# Altium schematic sheet gff.SchDoc(gff)
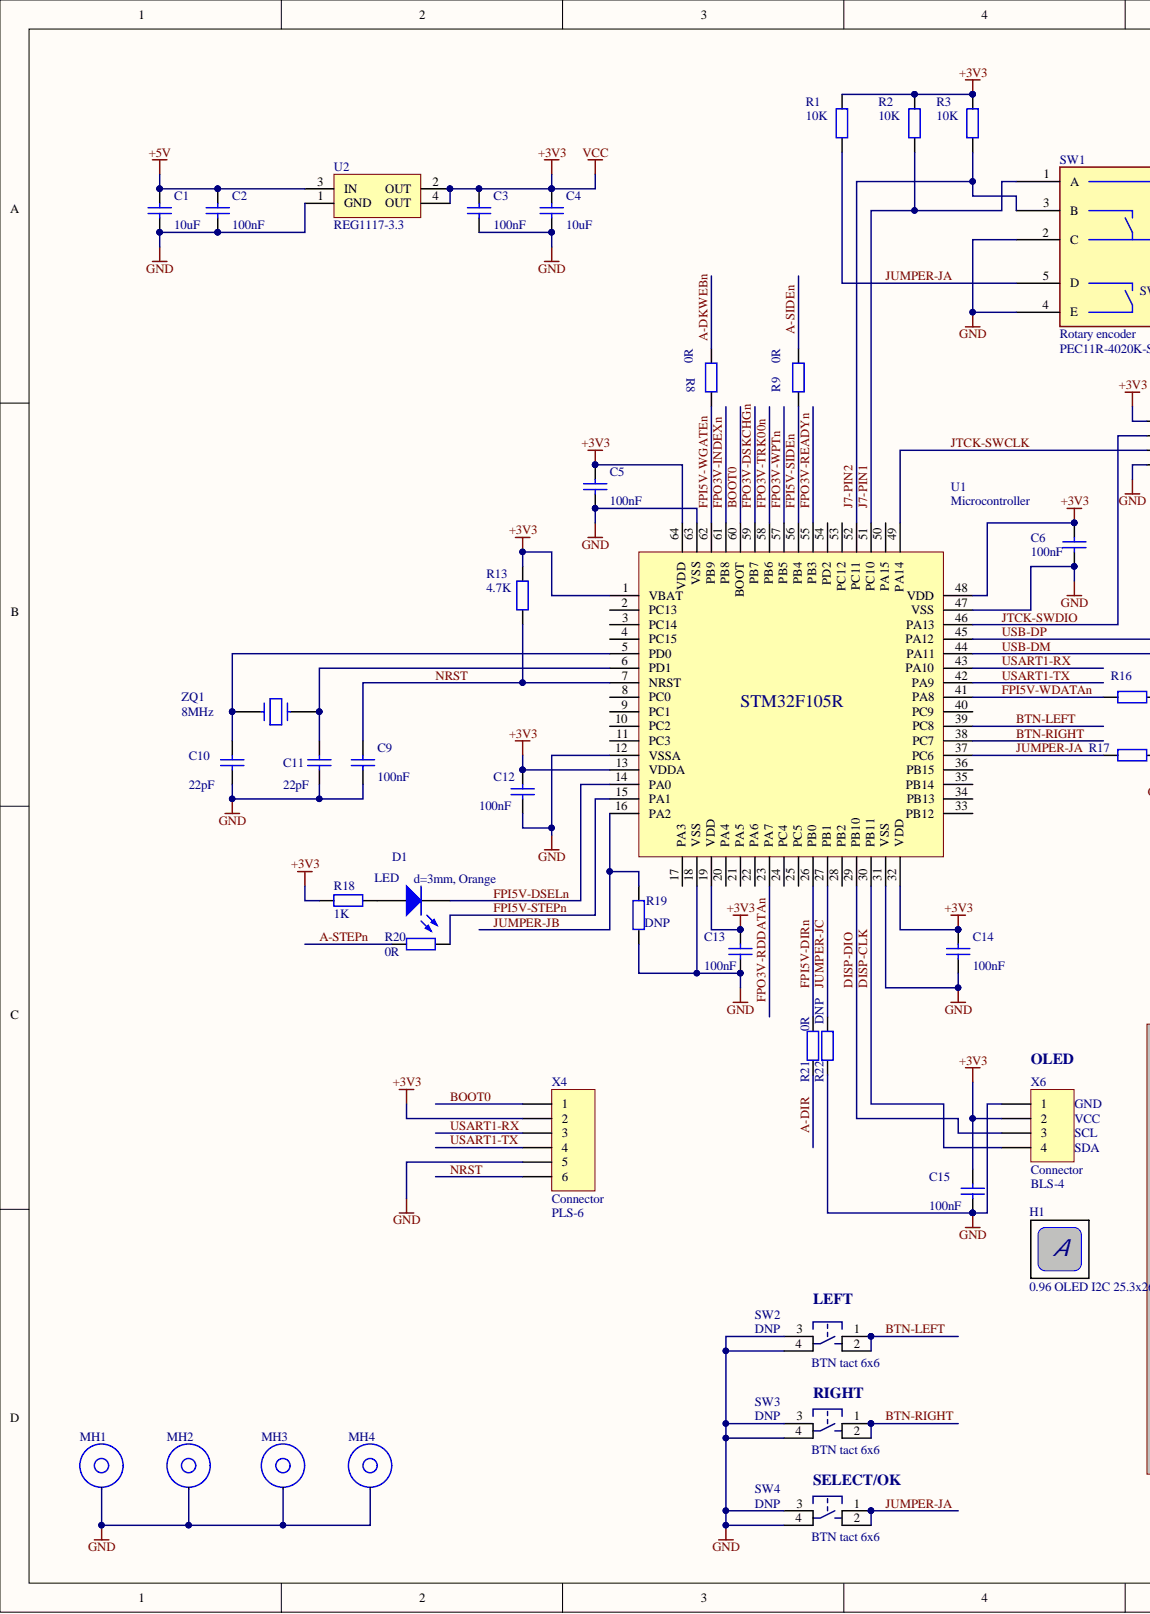
[diagram p0: gff.SchDoc, left]
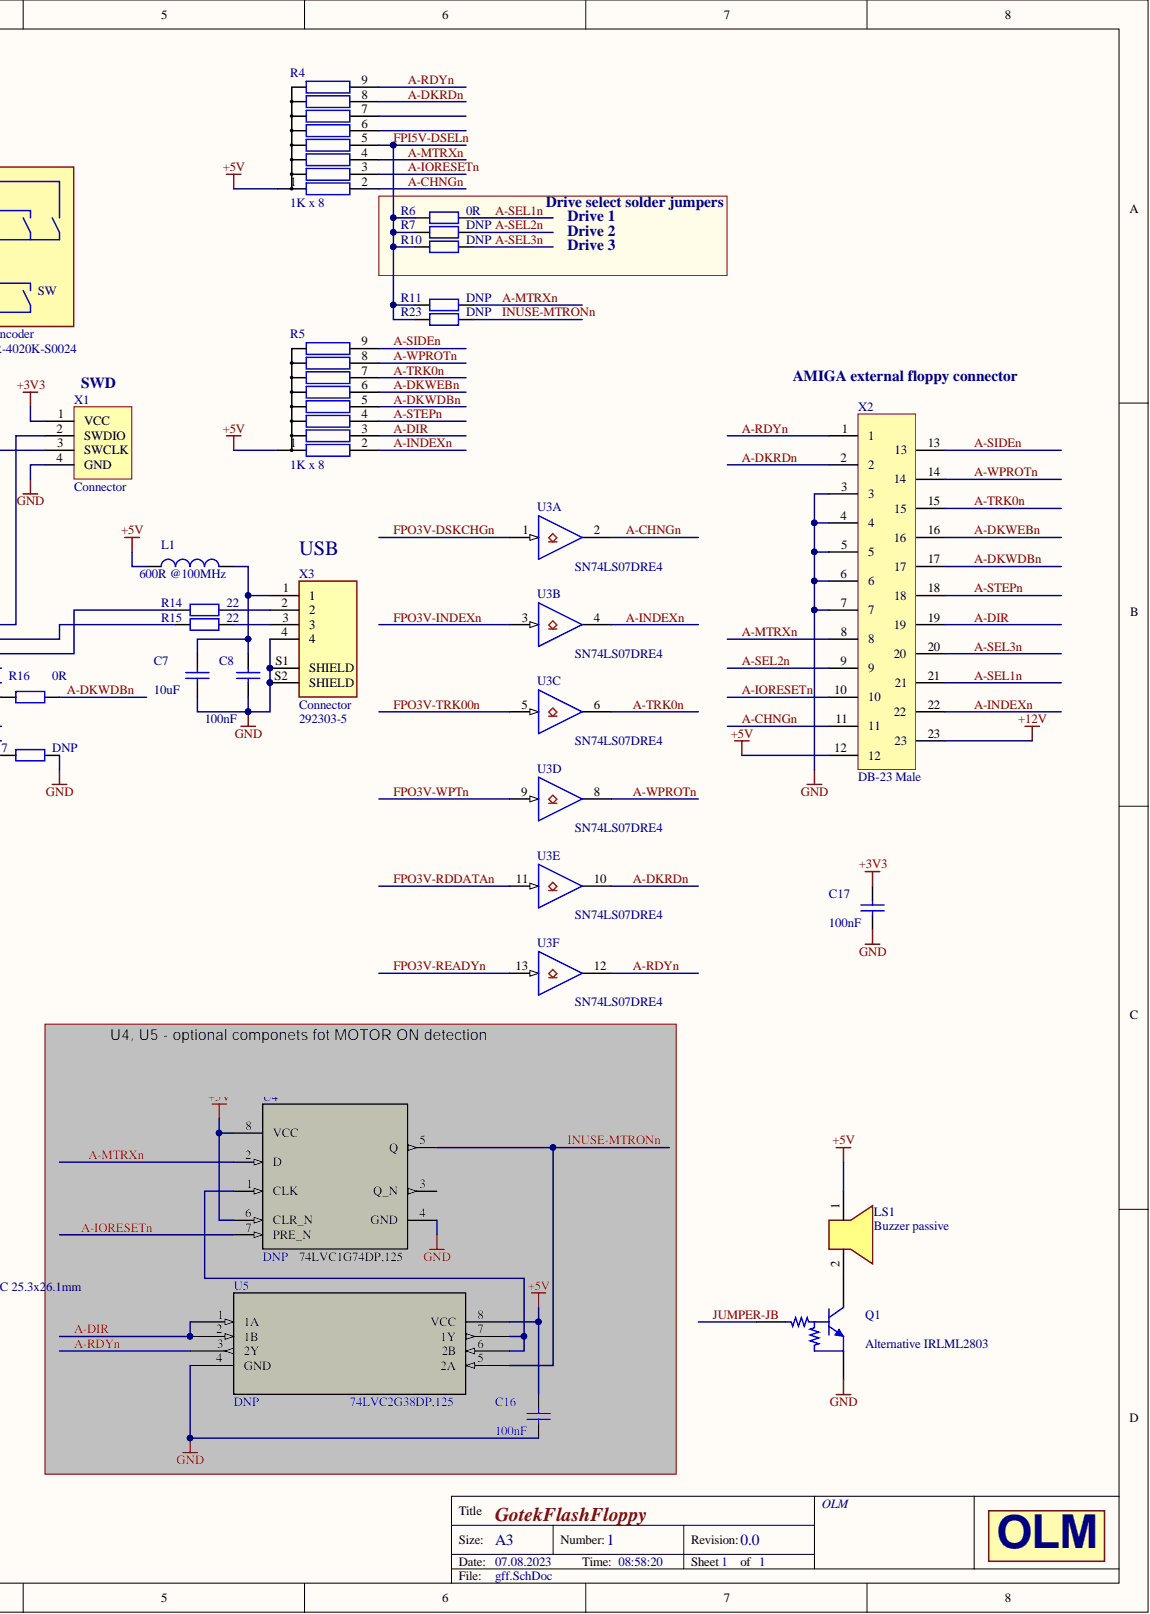
[diagram p1: gff.SchDoc, right]

## Parts
C1  value 10uF  [SM/C_1206]  (hidden comment: Capacitor)
C2  value 100nF  [SM/C_0603]  (hidden comment: Capacitor)
C3  value 100nF  [SM/C_0603]  (hidden comment: Capacitor)
C4  value 10uF  [SM/C_1206]  (hidden comment: Capacitor)
C5  value 100nF  [SM/C_0603]  (hidden comment: Capacitor)
C6  value 100nF  [SM/C_0603]  (hidden comment: Capacitor)
C7  value 10uF  [SM/C_1206]  (hidden comment: Capacitor)
C8  value 100nF  [SM/C_0603]  (hidden comment: Capacitor)
C9  value 100nF  [SM/C_0603]  (hidden comment: Capacitor)
C10  value 22pF  [SM/C_0603]  (hidden comment: Capacitor)
C11  value 22pF  [SM/C_0603]  (hidden comment: Capacitor)
C12  value 100nF  [SM/C_0603]  (hidden comment: Capacitor)
C13  value 100nF  [SM/C_0603]  (hidden comment: Capacitor)
C14  value 100nF  [SM/C_0603]  (hidden comment: Capacitor)
C15  value 100nF  [SM/C_0603]  (hidden comment: Capacitor)
C16  value 100nF  [SM/C_0603]  (hidden comment: Capacitor)
C17  value 100nF  [SM/C_0603]  (hidden comment: Capacitor)
D1  LED  value d=3mm, Orange  [LED_d3_green_sp8.64]
H1  value 0.96 OLED I2C 25.3x26.1mm  PArt: 0.96 OLED I2C 25.3x26.1mm  (hidden comment: OLED display)  (mechanical)
L1  value 600R @100MHz  [SM/L_1210]  (hidden comment: Ferrite bead)
LS1  Buzzer passive  [BUZZER_9mm]
MH1  MTHOLE_3  [MTHOLE_3.5MM/5MM]  (value not drawn)  (no BOM)
MH2  MTHOLE_3  [MTHOLE_3.5MM/5MM]  (value not drawn)  (no BOM)
MH3  MTHOLE_3  [MTHOLE_3.5MM/5MM]  (value not drawn)  (no BOM)
MH4  MTHOLE_3  [MTHOLE_3.5MM/5MM]  (value not drawn)  (no BOM)
Q1  Transistor  [SM/SOT23_123]  (value not drawn)
R1  value 10K  [SM/R_0603]  (hidden comment: Resistor)
R2  value 10K  [SM/R_0603]  (hidden comment: Resistor)
R3  value 10K  [SM/R_0603]  (hidden comment: Resistor)
R4  value 1K x 8  [RES_ARRAY_SIP9]  (hidden comment: Resistor network)
R5  value 1K x 8  [RES_ARRAY_SIP9]  (hidden comment: Resistor network)
R6  value 0R  [SM/R_0603]  (hidden comment: Resistor)
R7  value DNP  [SM/R_0603]  (hidden comment: Resistor)  (no BOM)
R8  value 0R  [SM/R_0603]  (hidden comment: Resistor)
R9  value 0R  [SM/R_0603]  (hidden comment: Resistor)
R10  value DNP  [SM/R_0603]  (hidden comment: Resistor)  (no BOM)
R11  value DNP  [SM/R_0603]  (hidden comment: Resistor)  (no BOM)
R13  value 4.7K  [SM/R_0603]  (hidden comment: Resistor)
R14  value 22  [SM/R_0603]  (hidden comment: Resistor)
R15  value 22  [SM/R_0603]  (hidden comment: Resistor)
R16  value 0R  [SM/R_0603]  (hidden comment: Resistor)
R17  value DNP  [SM/R_0603]  (hidden comment: Resistor)  (no BOM)
R18  value 1K  [SM/R_0603]  (hidden comment: Resistor)
R19  value DNP  [SM/R_0603]  (hidden comment: Resistor)  (no BOM)
R20  value 0R  [SM/R_0603]  (hidden comment: Resistor)
R21  value 0R  [SM/R_0603]  (hidden comment: Resistor)
R22  value DNP  [SM/R_0603]  (hidden comment: Resistor)  (no BOM)
R23  value DNP  [SM/R_0603]  (hidden comment: Resistor)  (no BOM)
SW1  Rotary encoder  value PEC11R-4020K-S0024  Part: PEC11R-4020K-S0024  [Encoder Bourns PEC11R-xx20F-S]
SW2  value BTN tact 6x6  Part: DNP  [BTN_6x6]  (hidden comment: Кнопка)  (no BOM)
SW3  value BTN tact 6x6  PArt: DNP  [BTN_6x6]  (hidden comment: Кнопка)  (no BOM)
SW4  value BTN tact 6x6  PArt: DNP  [BTN_6x6]  (hidden comment: Кнопка)  (no BOM)
U1  Microcontroller  [LQFP-64]
U2  value REG1117-3.3  [SM/SOT223_1234]  (hidden comment: LDO)
U3  value SN74LS07DRE4  Part: SN74LS07DRE4  [SOG.050/14/WG.244/L.325]  (hidden comment: IC)  (parts A,B,C,D,E,F)
U4  74LVC1G74DP,125  PArt: DNP  [TSSOP-8-1]  (no BOM)
U5  74LVC2G38DP,125  Part: DNP  [TSSOP-8-1]  (no BOM)
X1  Connector  [PLS-4]
X2  Connector  value DB-23 Male  Part: DB-23 Male  [SM/DB-23_EDGE]
X3  Connector  value 292303-5  MP: 292303-5  [TE_ 292303-5]
X4  Connector  value PLS-6  [HDR1X6]
X6  Connector  value BLS-4  [OLED-128x64-0.96_SMALL]
ZQ1  value 8MHz  [CRYSTAL_HC-49S]  (hidden comment: CRYSTAL)

## Nets
+3V3: C3.2 C4.2 C5.2 C6.2 C12.2 C13.2 C14.2 C15.2 C17.2 R1.1 R2.1 R3.1 R13.1 R18.1 U1.1(VBAT) U1.13(VDDA) U1.19(VDD) U1.32(VDD) U1.48(VDD) U1.64(VDD) U2.2(OUT) U2.4(OUT) U3.14 X1.1(VCC) X4.2 X6.2
+5V: C1.2 C2.2 C16.2 L1.1 LS1.1 R4.1 R5.1 U2.3(IN) U4.6(CLR_N) U4.8(VCC) U5.8(VCC) X2.12
+12V: X2.23
GND: C1.1 C2.1 C3.1 C4.1 C5.1 C6.1 C7.1 C8.1 C9.1 C10.2 C11.2 C12.1 C13.1 C14.1 C15.1 C16.1 C17.1 MH1.1 MH2.1 MH3.1 MH4.1 Q1.2 R17.2 R19.1 R22.1 SW1.2(C) SW1.4(E) SW2.3 SW2.4 SW3.3 SW3.4 SW4.3 SW4.4 U1.12(VSSA) U1.18(VSS) U1.31(VSS) U1.47(VSS) U1.63(VSS) U2.1(GND) U3.7 U4.4(GND) U5.4(GND) X1.4(GND) X2.3 X2.4 X2.5 X2.6 X2.7 X3.4 X3.S1(SHIELD) X3.S2(SHIELD) X4.5 X6.1
A-CHNGN: R4.2 U3.2 X2.11
A-DIR: R5.3 R21.1 U5.1(1A) U5.2(1B) X2.19
A-DKRDN: R4.8 U3.10 X2.2
A-DKWDBN: R5.5 R16.2 X2.17
A-DKWEBN: R5.6 R8.2 X2.16
A-INDEXN: R5.2 U3.4 X2.22
A-IORESETN: R4.3 U4.7(PRE_N) X2.10
A-MTRXN: R4.4 R11.2 U4.2(D) X2.8
A-RDYN: R4.9 U3.12 U5.3(2Y) X2.1
A-SEL1N: R6.2 X2.21
A-SEL2N: R7.2 X2.9
A-SEL3N: R10.2 X2.20
A-SIDEN: R5.9 R9.2 X2.13
A-STEPN: R5.4 R20.1 X2.18
A-TRK0N: R5.7 U3.6 X2.15
A-WPROTN: R5.8 U3.8 X2.14
BOOT0: U1.60(BOOT) X4.1
BTN-LEFT: SW2.1 SW2.2 U1.39(PC8)
BTN-RIGHT: SW3.1 SW3.2 U1.38(PC7)
DISP-CLK: U1.30(PB11) X6.4
DISP-DIO: U1.29(PB10) X6.3
FPI5V-DIRN: R21.2 U1.26(PB0)
FPI5V-DSELN: D1.2 R4.5 R6.1 R7.1 R10.1 R11.1 R23.1 U1.14(PA0)
FPI5V-SIDEN: R9.1 U1.56(PB4)
FPI5V-STEPN: R20.2 U1.15(PA1)
FPI5V-WDATAN: R16.1 U1.41(PA8)
FPI5V-WGATEN: R8.1 U1.62(PB9)
FPO3V-DSKCHGN: U1.59(PB7) U3.1
FPO3V-INDEXN: U1.61(PB8) U3.3
FPO3V-RDDATAN: U1.23(PA7) U3.11
FPO3V-READYN: U1.55(PB3) U3.13
FPO3V-TRK00N: U1.58(PB6) U3.5
FPO3V-WPTN: U1.57(PB5) U3.9
INUSE-MTRONN: R23.2 U4.5(Q) U5.5(2A)
J7-PIN1: R2.2 SW1.1(A) U1.51(PC10)
J7-PIN2: R3.2 SW1.3(B) U1.52(PC11)
JTCK-SWCLK: U1.49(PA14) X1.3(SWCLK)
JTCK-SWDIO: U1.46(PA13) X1.2(SWDIO)
JUMPER-JA: R1.2 R17.1 SW1.5(D) SW4.1 SW4.2 U1.37(PC6)
JUMPER-JB: Q1.1 R19.2 U1.16(PA2)
JUMPER-JC: R22.2 U1.27(PB1)
NRST: C9.2 R13.2 U1.7(NRST) X4.6
USART1-RX: U1.43(PA10) X4.3
USART1-TX: U1.42(PA9) X4.4
USB-DM: R14.1 U1.44(PA11)
USB-DP: R15.1 U1.45(PA12)
NetC7_2: C7.2 C8.2 L1.2 X3.1
NetC10_1: C10.1 U1.5(PD0) ZQ1.2
NetC11_1: C11.1 U1.6(PD1) ZQ1.1
NetD1_1: D1.1 R18.2
NetLS1_2: LS1.2 Q1.3
NetR14_2: R14.2 X3.2
NetR15_2: R15.2 X3.3
NetU4_1: U4.1(CLK) U5.6(2B) U5.7(1Y)

Not connected: R4 6 7; U1 2(PC13) 3(PC14) 4(PC15) 8(PC0) 9(PC1) 10(PC2) 11(PC3) 17(PA3) 20(PA4) 21(PA5) 22(PA6) 24(PC4) 25(PC5) 28(PB2) 33(PB12) 34(PB13) 35(PB14) 36(PB15) 40(PC9) 50(PA15) 53(PC12) 54(PD2); U4 3(Q_N)

## Library data of the parts
C1 C4 C7 = Cap ceramic: Ceramic capacitor SMD; Manufacturer: Kemet; Part: C1206C106K4RACTU; Component Kind: Standard; Library Name: New.SCHLIB
C2 C3 C5 C6 C8 C9 C12-C17 = Cap ceramic: Ceramic capacitor SMD; Manufacturer: Samsung; Part: CL10B104KB8NNNL; Component Kind: Standard; Library Name: New.SCHLIB
C10 C11 = Cap: Ceramic capacitor SMD; Manufacturer: Yageo; Part: CC0603JPNPO9BN220; Component Kind: Standard; LatestRevisionDate: 17-Jul-2002; LatestRevisionNote: Re-released for DXP Platform.; Library Name: Miscellaneous Devices.IntLib; PackageReference: RAD-0.3; Published: 8-Jun-2000; Signal Integrity: Cap; Simulation: CAP; Publisher: Altium Limited
D1 = LED0: LED d3mm; Part: LED 3mm Orange; Примечание: Kingbright; Component Kind: Standard; LatestRevisionDate: 08-Jul-2005; LatestRevisionNote: Mech Layer 1 removed.; Library Name: Miscellaneous Devices.IntLib; PackageDescription: LED; 2 Leads; PackageReference: LED-0; Published: 8-Jun-2000; Simulation: LED0; Publisher: Altium Limited
H1 = Display: 0.96 OLED display; Library Name: MECH_Library_home.SchLib
L1 = Inductor: Manufacturer: Bourns; Part: BLM31PG601SN1L; Code_IEC: 1005; Code_JEITA: 0402; Component Kind: Standard; Description: Ferrite bead; Library Name: Miscellaneous Devices.IntLib; PackageDescription: Chip Inductor; PackageReference: 1206; Signal Integrity: Inductor; Simulation: INDUCTOR
LS1 = Buzzer_1: 4-6V small buzzer (9mm); Manufacturer: Soberton; Part: GT-0905A; Value: GT-0905A; Component Kind: Standard; Library Name: New.SCHLIB
Q1 = PDTC114ET: NPN Resistor-equipped Transistor; Part: Alternative IRLML2803; Component Kind: Standard; ComponentLink1Description: Manufacturer Link; ComponentLink1URL: http://www.semiconductors.philips.com/; ComponentLink2Description: Datasheet; ComponentLink2URL: http://www.semiconductors.philips.com/acrobat/datasheets/PDTC114E_SERIES_10.pdf; DatasheetVersion: 15-Apr-1999; Library Name: Philips Discrete BJT - Low Power.IntLib; PackageDescription: SOT-23; 3 Leads; Body 3.0 x 2.5 mm, inc. leads (LxW max); PackageReference: SOT23; PackageVersion: May-1999; Published: 17-Sep-2003; Signal Integrity: PDTC114ET; Simulation: PDTC114ET; Value: PDTC114ET; Publisher: Altium Limited
R1-R3 = Res2: Resistor SMD; PArt: 10k 5% 0603; Component Kind: Standard; LatestRevisionDate: 17-Jul-2002; LatestRevisionNote: Re-released for DXP Platform.; Library Name: Miscellaneous Devices.IntLib; PackageReference: AXIAL-0.4; Published: 8-Jun-2000; Signal Integrity: Res; Simulation: RESISTOR; Publisher: Altium Limited
R4 R5 = RES_PACK_8: SIP bussed resistor network. 8 resistors; Manufacturer: Bourns; Part: 4609X-101-102LF; Component Kind: Standard; Library Name: New.SCHLIB
R6 R8 R9 R16 R20 R21 = Res2: Resistor SMD; Part: 0R 5% 0603; Component Kind: Standard; LatestRevisionDate: 17-Jul-2002; LatestRevisionNote: Re-released for DXP Platform.; Library Name: Miscellaneous Devices.IntLib; PackageReference: AXIAL-0.4; Published: 8-Jun-2000; Signal Integrity: Res; Simulation: RESISTOR; Publisher: Altium Limited
R7 R10 R11 R17 R19 R22 R23 = Res2: Resistor SMD; LatestRevisionDate: 17-Jul-2002; LatestRevisionNote: Re-released for DXP Platform.; Library Name: Miscellaneous Devices.IntLib; PackageReference: AXIAL-0.4; Part: DNP 0603; Published: 8-Jun-2000; Signal Integrity: Res; Simulation: RESISTOR; Publisher: Altium Limited
R13 = Res2: Resistor SMD; Part: 4.7k 5% 0603; Component Kind: Standard; LatestRevisionDate: 17-Jul-2002; LatestRevisionNote: Re-released for DXP Platform.; Library Name: Miscellaneous Devices.IntLib; PackageReference: AXIAL-0.4; Published: 8-Jun-2000; Signal Integrity: Res; Simulation: RESISTOR; Publisher: Altium Limited
R14 R15 = Res2: Resistor SMD; Part: 22R 5% 0603; Component Kind: Standard; LatestRevisionDate: 17-Jul-2002; LatestRevisionNote: Re-released for DXP Platform.; Library Name: Miscellaneous Devices.IntLib; PackageReference: AXIAL-0.4; Published: 8-Jun-2000; Signal Integrity: Res; Simulation: RESISTOR; Publisher: Altium Limited
R18 = Res2: Resistor SMD; Part: 1k 5% 0603; Component Kind: Standard; LatestRevisionDate: 17-Jul-2002; LatestRevisionNote: Re-released for DXP Platform.; Library Name: Miscellaneous Devices.IntLib; PackageReference: AXIAL-0.4; Published: 8-Jun-2000; Signal Integrity: Res; Simulation: RESISTOR; Publisher: Altium Limited
SW1 = ENCODER_SW: Rotary encoder with a switch; Manufacturer: Bourns; Component Kind: Standard; Library Name: New_home.SCHLIB
SW2-SW4 = Button 4 pin: small tac switch 4pin; Library Name: New_home.SCHLIB
U1 = STM32F105RxT: ST MCU with CAN and USB; Manufacturer: ST Micro; Part: STM32F105RBT6; Value: STM32F105RBT6; Component Kind: Standard; Library Name: STM32.SchLib
U2 = REG1117-3.3: 800mA LDO Regulator; Manufacturer: Texas Instruments; Component Kind: Standard; ComponentLink1Description: Manufacturer Link; ComponentLink1URL: http://www.ti.com/; ComponentLink2Description: Datasheet; ComponentLink2URL: http://www-s.ti.com/sc/ds/REG1117.pdf; DatasheetVersion: Sep-1997; LatestRevisionDate: 17-Jul-2002; LatestRevisionNote: Re-released for DXP Platform.; Library Name: BB Power Mgt Voltage Regulator.IntLib; PackageDescription: SOT-223/SC-73; 4 Leads; Body 6.7 x 7.3 mm, inc. leads (LxW max); PackageReference: ZZ311; PackageVersion: revC; Part: REG1117-3.3; Published: 8-Jun-2000; Publisher: Altium Limited
U3 = M74HC07M1R: Hex Buffer (Open Drain); Manufacturer: Texas Instruments; Code_IPC: SOIC127P600-14; Component Kind: Standard; ComponentLink1Description: Manufacturer Link; ComponentLink1URL: http://www.st.com/; DatasheetVersion: Feb-1993; LatestRevisionDate: 13-Apr-2006; LatestRevisionNote: IPC-7351 Footprint Added.; Library Name: ST Logic Gate.IntLib; PackageDescription: 14-Pin Small Outline Integrated Circuit 1.27 mm Pitch; PackageReference: SO14; PackageVersion: Sep-1998; PCB3D: SO14; Published: 8-Jun-2000; Signal Integrity: M74HC07M1R; Publisher: Altium Limited
U4 = SN74LVC1G74DCTR: No Description Available; Manufacturer: Texas Instruments; CompType: IC; PIN_COUNT: 8; Library Name: New.SCHLIB; MountType: SMT; PackageReference: DCT0008A; PartNumber: SN74LVC1G74DCTR; RefDes: RefDes; RoHS: Y; Type: DEV; Value: 74LVC1G74DP,125; Copyright: Copyright (C) 2018 Accelerated Designs. All rights reserved
U5 = SN74LVC2G38DCUR: Dual 2-Input NAND Gate with Open Drain Output; Manufacturer: Texas Instruments; FAMILY_NAME: LL NAND GATE; INDUSTRY_STD_PKG_TYPE: US8; NXP: 74LVC2G38DP,125‎; PACKAGE_DESIGNATOR: DCU; PIN_COUNT: 8; Datasheet_URL: http://www.ti.com/lit/gpn/SN74LVC2G38; GENERIC_PART_NUMBER: SN74LVC2G38; Library Name: New.SCHLIB; Manufacturer_Part_Number: SN74LVC2G38DCUR; RefDes: RefDes; Type: DEV; Value: 74LVC2G38DP,125; Vendor: Texas Instruments; Copyright: Copyright (C) 2018 Accelerated Designs. All rights reserved
X1 = SWD_4: SWD connector; Manufacturer: TE Connectivity; Part: 5-146285-4; Value: PLS-4; Component Kind: Standard; Library Name: Connectors.SchLib
X2 = DB-23: Amiga external floppy connector. 23 pin Male.; Component Kind: Standard; Library Name: Connectors_home.SchLib
X3 = 292303-7: Conn USB 2.0 Type A; Manufacturer: TE Connectivity; Availability: Unavailable; Component Kind: Standard; Library Name: 292303-7.IntLib; MF: TE Connectivity; Package: None; Part: 292303-5; Price: None; SnapEDA_Link: https://www.snapeda.com/parts/292303-7/TE%20Connectivity%20AMP%20Connectors/view-part/814934/?ref=snap
X4 = Header 6: Header, 6-Pin; Manufacturer: TE Connectivity; Part: 5-146285-6; Component Kind: Standard; LatestRevisionDate: 17-Jul-2002; LatestRevisionNote: Re-released for DXP Platform.; Library Name: Miscellaneous Connectors.IntLib; Signal Integrity: Connector; Publisher: Altium Limited
X6 = Header 4: Female Header, 4-Pin; Manufacturer: Preci-Dip; Part: 801-87-004-10-001101; Value: BLS-4; Component Kind: Standard; LatestRevisionDate: 17-Jul-2002; LatestRevisionNote: Re-released for DXP Platform.; Library Name: Miscellaneous Connectors.IntLib; Signal Integrity: Connector; Publisher: Altium Limited
ZQ1 = CRYSTAL: Manufacturer: Citizen Finedevice Co Ltd; Part: HC-49/U-S8000000ABJB; Component Kind: Standard; Description: Crystal; Library Name: New.SCHLIB
MH1-MH4 = MTHOLE_3: Library Name: New_home.SCHLIB
